# Altium schematic sheet Sheet2.SchDoc(Sheet2)
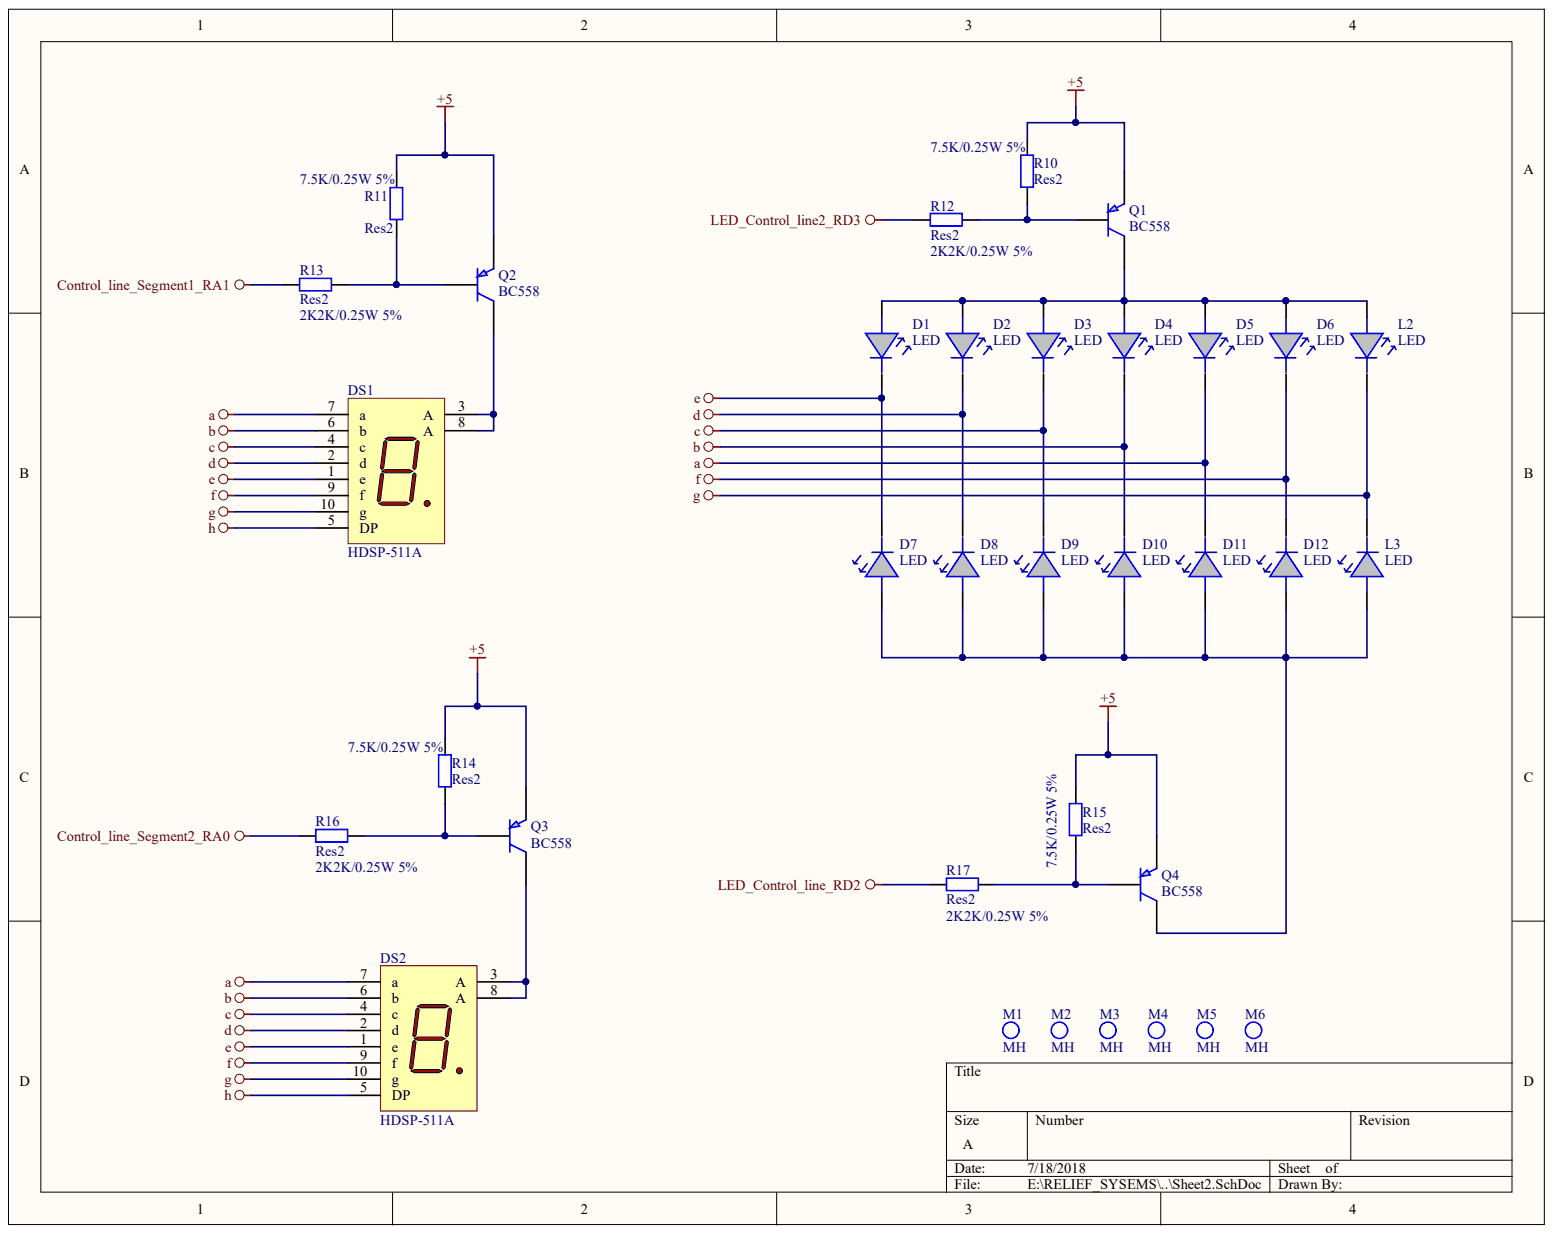
[diagram p0: Sheet2.SchDoc]

## Parts
D1  LED  [LED5MM]
D2  LED  [LED5MM]
D3  LED  [LED5MM]
D4  LED  [LED5MM]
D5  LED  [LED5MM]
D6  LED  [LED5MM]
D7  LED  [LED5MM]
D8  LED  [LED5MM]
D9  LED  [LED5MM]
D10  LED  [LED5MM]
D11  LED  [LED5MM]
D12  LED  [LED5MM]
DS1  HDSP-511A  [HDSP-51]
DS2  HDSP-511A  [HDSP-51]
L2  LED  [LED5MM]
L3  LED  [LED5MM]
M1  MH  [Mounting hole]
M2  MH  [Mounting hole]
M3  MH  [Mounting hole]
M4  MH  [Mounting hole]
M5  MH  [Mounting hole]
M6  MH  [Mounting hole]
Q1  BC558  [TRANSISTOR_BC548]
Q2  BC558  [TRANSISTOR_BC548]
Q3  BC558  [TRANSISTOR_BC548]
Q4  BC558  [TRANSISTOR_BC548]
R10  Res2  value 7.5K/0.25W 5%  [AXIAL-0.4]
R11  Res2  value 7.5K/0.25W 5%  [AXIAL-0.4]
R12  Res2  value 2K2K/0.25W 5%  [AXIAL-0.4]
R13  Res2  value 2K2K/0.25W 5%  [AXIAL-0.4]
R14  Res2  value 7.5K/0.25W 5%  [AXIAL-0.4]
R15  Res2  value 7.5K/0.25W 5%  [AXIAL-0.4]
R16  Res2  value 2K2K/0.25W 5%  [AXIAL-0.4]
R17  Res2  value 2K2K/0.25W 5%  [AXIAL-0.4]

## Nets
+5: Q1.3 Q2.3 Q3.3 Q4.3 R10.2 R11.2 R14.2 R15.2
A: D5.2 D11.2 DS1.7(a) DS2.7(a)
B: D4.2 D10.2 DS1.6(b) DS2.6(b)
C: D3.2 D9.2 DS1.4(c) DS2.4(c)
CONTROL_LINE_SEGMENT1_RA1: R13.2
CONTROL_LINE_SEGMENT2_RA0: R16.2
D: D2.2 D8.2 DS1.2(d) DS2.2(d)
E: D1.2 D7.2 DS1.1(e) DS2.1(e)
F: D6.2 D12.2 DS1.9(f) DS2.9(f)
G: DS1.10(g) DS2.10(g) L2.2 L3.2
H: DS1.5(DP) DS2.5(DP)
LED_CONTROL_LINE2_RD3: R12.2
LED_CONTROL_LINE_RD2: R17.2
NetD1_1: D1.1 D2.1 D3.1 D4.1 D5.1 D6.1 L2.1 Q1.1
NetD7_1: D7.1 D8.1 D9.1 D10.1 D11.1 D12.1 L3.1 Q4.1
NetDS1_3: DS1.3(A) DS1.8(A) Q2.1
NetDS2_3: DS2.3(A) DS2.8(A) Q3.1
NetQ1_2: Q1.2 R10.1 R12.1
NetQ2_2: Q2.2 R11.1 R13.1
NetQ3_2: Q3.2 R14.1 R16.1
NetQ4_2: Q4.2 R15.1 R17.1

## Library data of the parts
D1-D12 L2 L3 = LED_5MM: Component Kind: Standard; Description: LED_5MM; Library Name: Dinesh_New_Integrated_Library.IntLib
DS1 DS2 = HDSP-511A: 14.22 mm General Purpose AlGaAs Red 7-Segment Display: CA, RH DP; LuminousIntensity: 3201 ucd (Minimum).; Component Kind: Standard; ComponentLink1Description: Manufacturer Link; ComponentLink1URL: http://www.agilent.com/; ComponentLink2Description: Datasheet; ComponentLink2URL: http://cp.literature.agilent.com/litweb/pdf/5988-2968EN.pdf; DatasheetDocument: 25-Jul-2001; LatestRevisionDate: 11-Oct-2004; LatestRevisionNote: Display outline superimposed on PCB Footprint.; LED_Colour: AlGaAs Red; Library Name: Agilent LED Display 7-Segment, 1-Digit.IntLib; PackageDocument: 25-Jul-2001; PackageReference: HDSP-51; Published: 26-May-2004; Simulation: HDSP_511A; Publisher: Altium Limited
Q1-Q4 = BC558: PNP; Component Kind: Standard; Library Name: Dinesh_New_Integrated_Library.IntLib
R10 R11 R14-R17 = Res2: Resistor; Component Kind: Standard; LatestRevisionDate: 17-Jul-2002; LatestRevisionNote: Re-released for DXP Platform.; Library Name: Miscellaneous Devices.IntLib; PackageDescription: Axial Device, Thru-Hole; 2 Leads; 0.4 in Pin Spacing; PackageReference: AXIAL-0.4; Published: 8-Jun-2000; Signal Integrity: Res; Simulation: RESISTOR; Publisher: Altium Limited
R12 R13 = Res2: Resistor; Value: 2K2K/0.25W 5%; Component Kind: Standard; LatestRevisionDate: 17-Jul-2002; LatestRevisionNote: Re-released for DXP Platform.; Library Name: Miscellaneous Devices.IntLib; PackageDescription: Axial Device, Thru-Hole; 2 Leads; 0.4 in Pin Spacing; PackageReference: AXIAL-0.4; Published: 8-Jun-2000; Signal Integrity: Res; Simulation: RESISTOR; Publisher: Altium Limited
M1-M6 = MH: Component Kind: Standard; Library Name: Dinesh_New_Integrated_Library.IntLib
